# Altium schematic sheet 5 SiPM.SchDoc
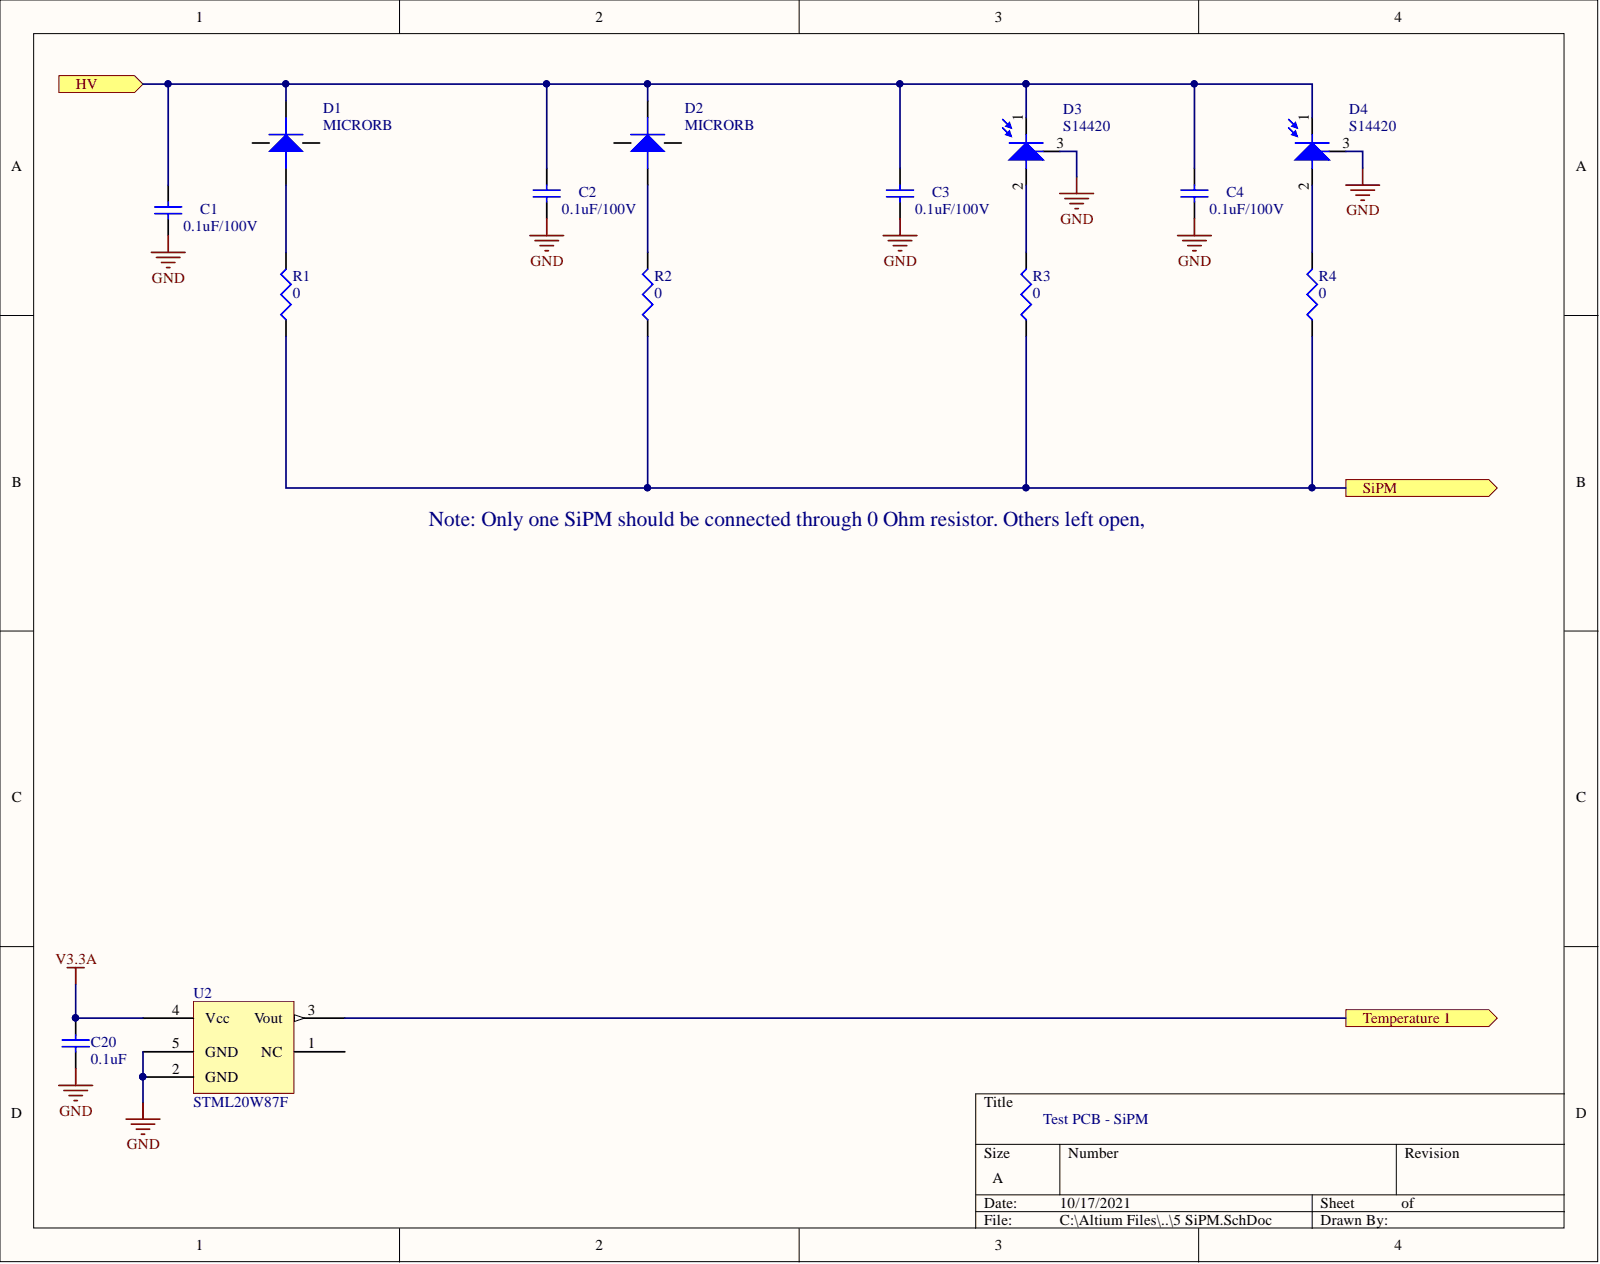
[diagram p0: 5 SiPM.SchDoc]

## Parts
C1  0.1uF/100V  [C0402]
C2  0.1uF/100V  [C0402]
C3  0.1uF/100V  [C0402]
C4  0.1uF/100V  [C0402]
C20  0.1uF  [C0402]
D1  MICRORB  [MICRORB]
D2  MICRORB  [MICRORB]
D3  S14420  [Photodiode 3pin 200mil]
D4  S14420  [Photodiode 3pin 200mil]
R1  0  [R0603]
R2  0  [R0603]
R3  0  [R0603]
R4  0  [R0603]
U2  STML20W87F  [SOT323-5]

## Ports
HV, SiPM, Temperature 1

## Nets
GND: C1.1 C2.1 C3.1 C4.1 C20.1 D3.3 D4.3 U2.2(GND) U2.5(GND)
V3.3A: C20.2 U2.4(Vcc)
HV: C1.2 C2.2 C3.2 C4.2 D1.3 D2.3 D3.1 D4.1 ; port HV
SiPM: R1.1 R2.1 R3.1 R4.1 ; port SiPM
Temperature 1: U2.3(Vout) ; port Temperature 1
NetD1_1: D1.1 R1.2
NetD2_1: D2.1 R2.2
NetD3_2: D3.2 R3.2
NetD4_2: D4.2 R4.2

Not connected: D1 2 4; D2 2 4; U2 1(NC)

## Library data of the parts
C1-C4 C20 = Cap SM: Capacitor, SM; Component Kind: Standard; LatestRevisionDate: 29-May-2009; LatestRevisionNote: IPC-7351 Footprint Added.; PackageDescription: Chip Capacitor; PackageReference: C1206; Published: 8-Jun-2000; Library Name: Graylark.IntLib; Publisher: Altium Limited
  C1-C4: Signal Integrity: Cap Semi; Simulation: CAP; Value: 0.1uF/100V
  C20: Value: 0.1uF
D1 D2 = MICRORB: SiPM; Component Kind: Standard; Library Name: Graylark.SchLib
R1-R4 = Res SM: Resistor; Component Kind: Standard; LatestRevisionDate: 29-May-2009; LatestRevisionNote: IPC-7351 Footprint Added.; PackageDescription: Chip Resistor; PackageReference: J1-0603; Published: 8-Jun-2000; Signal Integrity: Res; Simulation: RESISTOR; Value: 0; Library Name: Graylark.IntLib; Publisher: Altium Limited
D3 D4 = S14420: Component Kind: Standard; Library Name: Graylark.IntLib
U2 = STML20W87F: Component Kind: Standard; Library Name: Graylark.IntLib
